# Altium schematic sheet Isolated_RS485.SchDoc
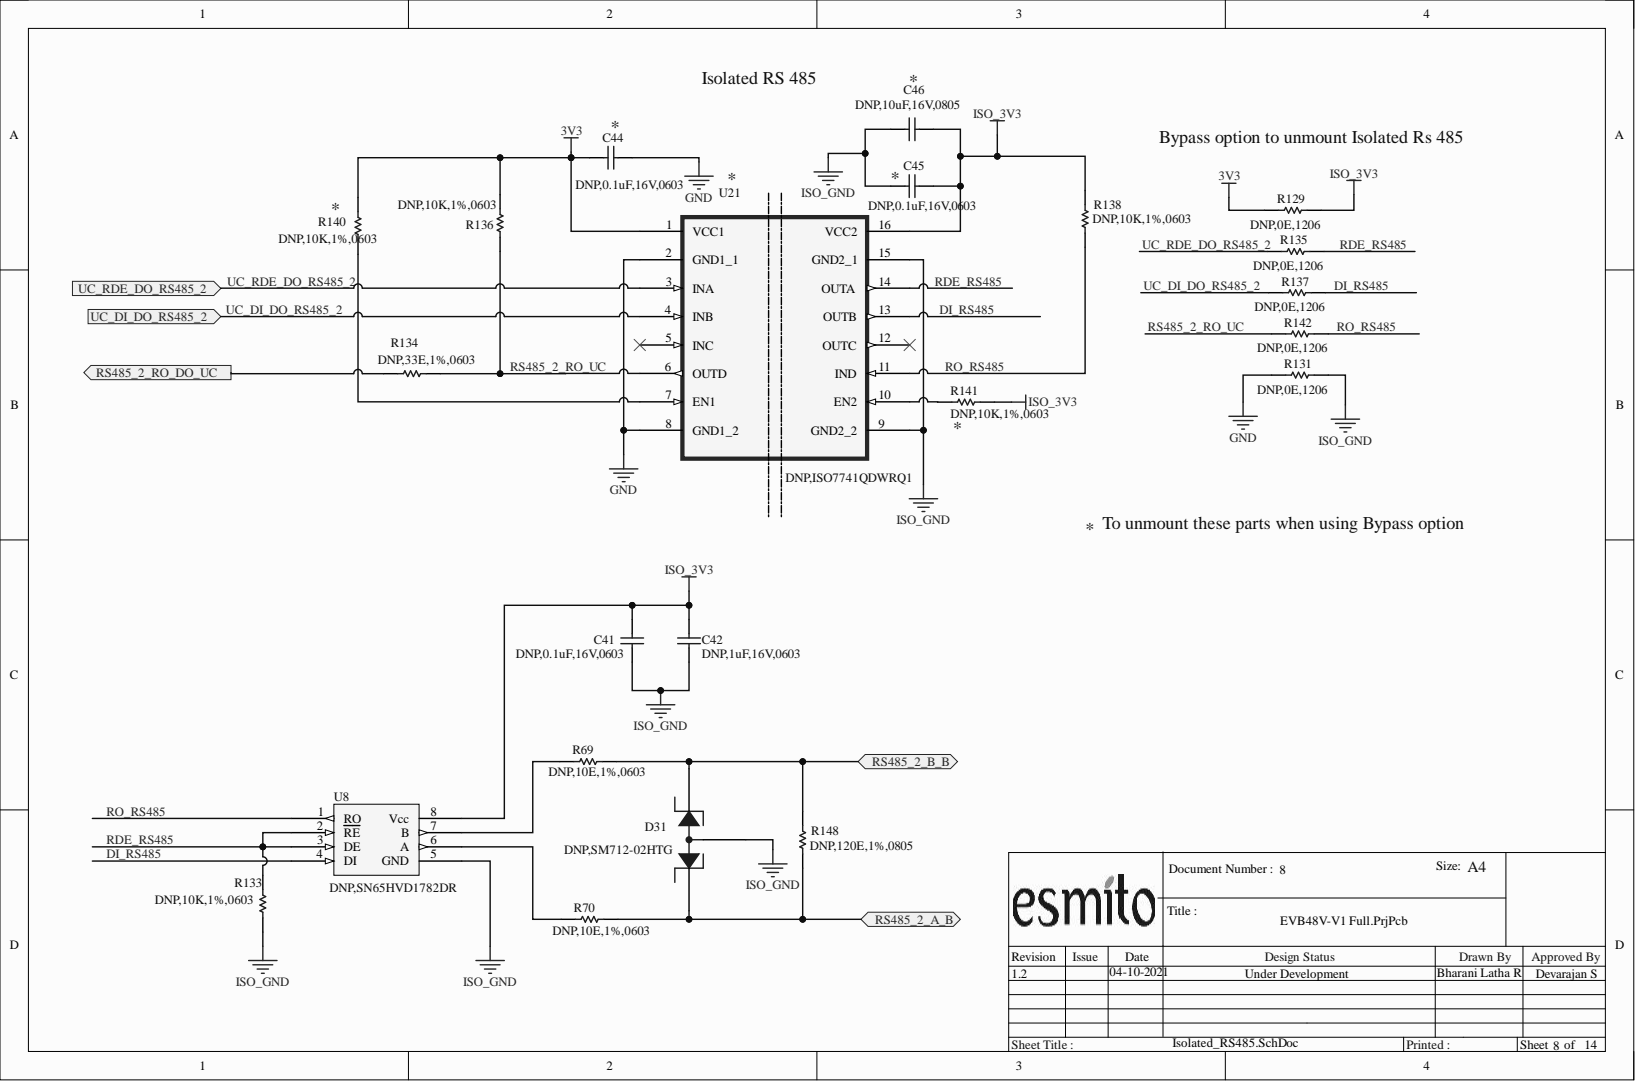
[diagram p0: Isolated_RS485.SchDoc]

## Parts
C41  value DNP,0.1uF,16V,0603  [0603_C_M]  (hidden comment: C_Semi)
C42  value DNP,1uF,16V,0603  [0603_C_M]  (hidden comment: C_Semi)
C44  value DNP,0.1uF,16V,0603  [0603_C_M]  (hidden comment: C_Semi)
C45  value DNP,0.1uF,16V,0603  [0603_C_M]  (hidden comment: C_Semi)
C46  value DNP,10uF,16V,0805  [0805_R_M]  (hidden comment: C_Semi)
D31  SM712-02HTG  value DNP,SM712-02HTG  [SOT23_3_L]
R69  value DNP,10E,1%,0603  [0603_R_M]  (hidden comment: R_Semi)
R70  value DNP,10E,1%,0603  [0603_R_M]  (hidden comment: R_Semi)
R129  R_Semi  [1206_C_M]  (value not drawn)
R131  value DNP,0E,1206  [1206_C_M]  (hidden comment: R_Semi)
R133  value DNP,10K,1%,0603  [0603_R_M]  (hidden comment: R_Semi)
R134  value DNP,33E,1%,0603  [0603_R_M]  (hidden comment: R_Semi)
R135  value DNP,0E,1206  [1206_C_M]  (hidden comment: R_Semi)
R136  value DNP,10K,1%,0603  [0603_R_M]  (hidden comment: R_Semi)
R137  value DNP,0E,1206  [1206_C_M]  (hidden comment: R_Semi)
R138  value DNP,10K,1%,0603  [0603_R_M]  (hidden comment: R_Semi)
R140  value DNP,10K,1%,0603  [0603_R_M]  (hidden comment: R_Semi)
R141  value DNP,10K,1%,0603  [0603_R_M]  (hidden comment: R_Semi)
R142  value DNP,0E,1206  [1206_C_M]  (hidden comment: R_Semi)
R148  value DNP,120E,1%,0805  [0805_R_M]  (hidden comment: R_Semi)
U8  value DNP,SN65HVD1782DR  [SOIC8_M]  (hidden comment: RS485)
U21  ISO7741QDWRQ1  value DNP,ISO7741QDWRQ1  [SOIC127P1030X265-16M]

## Ports
RS485_2_A_B, RS485_2_B_B, RS485_2_RO_DO_UC, UC_DI_DO_RS485_2, UC_RDE_DO_RS485_2

## Nets
3V3: C44.1 R129.2 R136.2 R140.2 U21.1(VCC1)
GND: C44.2 R131.2 U21.2(GND1_1) U21.8(GND1_2)
ISO_3V3: C41.2 C42.2 C45.2 C46.1 R129.1 R138.2 R141.1 U8.8(Vcc) U21.16(VCC2)
ISO_GND: C41.1 C42.1 C45.1 C46.2 D31.3 R131.1 R133.1 U8.5(GND) U21.9(GND2_2) U21.15(GND2_1)
DI_RS485: R137.1 U8.4(DI) U21.13(OUTB)
RDE_RS485: R133.2 R135.1 U8.2(RE) U8.3(DE) U21.14(OUTA)
RO_RS485: R138.1 R142.1 U8.1(RO) U21.11(IND)
RS485_2_A_B: D31.2 R70.1 R148.1 ; port RS485_2_A_B
RS485_2_B_B: D31.1 R69.1 R148.2 ; port RS485_2_B_B
RS485_2_RO_DO_UC: R134.2 ; port RS485_2_RO_DO_UC
RS485_2_RO_UC: R134.1 R136.1 R142.2 U21.6(OUTD)
UC_DI_DO_RS485_2: R137.2 U21.4(INB) ; port UC_DI_DO_RS485_2
UC_RDE_DO_RS485_2: R135.2 U21.3(INA) ; port UC_RDE_DO_RS485_2
NetR69_2: R69.2 U8.7(B)
NetR70_2: R70.2 U8.6(A)
NetR140_1: R140.1 U21.7(EN1)
NetR141_2: R141.2 U21.10(EN2)

Not connected: U21 5(INC) 12(OUTC)

## Library data of the parts
C41 C44 C45 = C_Semi: MLCC - 0603 100nF 16V X7R 10%; Alternate Manufacturer: AVX; Alternate Part Number: 0603YC104K4T2A; Manufacturer: Yageo; Part Number: CC603KRX7R7BB104; Component Kind: Standard; Signal Integrity: Cap Semi; Simulation: CAP; Library Name: Grinntech_Global_Library.IntLib
C42 = C_Semi: MLCC - 0603 1uF 16V X5R 10%; Alternate Manufacturer: Murata; Alternate Part Number: GCM188R71C105KA64D; Manufacturer: Yageo; Part Number: CC603KRX5R7BB105; Component Kind: Standard; Signal Integrity: Cap Semi; Simulation: CAP; Library Name: Grinntech_Global_Library.IntLib
C46 = C_Semi: MLCC - 0805 16V 10uF X7R 10 %; Alternate Manufacturer: Taiyo Yuden; Alternate Part Number: EMK212BB7106KG-T; Manufacturer: Yageo; Part Number: CC0805KKX7R7BB106; Component Kind: Standard; Signal Integrity: Cap Semi; Simulation: CAP; Library Name: Grinntech_Global_Library.IntLib
D31 = SM712-02HTG: ESD Suppressors / TVS Diodes 600W 2 Channel 12V; Alternate Manufacturer: Littelfuse; Manufacturer: STMicroelectronics; Part Number: ESDA6V1L; Alternate Part Number: SM712-02HTG; Component Kind: Standard
R69 R70 = R_Semi: R, THK FLM, 10E, 1%, 250mW, 0603; Manufacturer: ROHM; Part Number: ESR03EZPF10R0; Component Kind: Standard; Signal Integrity: Res Semi; Simulation: RES; Library Name: Grinntech_Global_Library.IntLib
R129 = R_Semi: R, THK FLM, 0E, 5%, 500mW, 1206; Manufacturer: Yageo; Part Number: AC1210JR-070RL; Value: DNP,0E,1206; Component Kind: Standard; Signal Integrity: Res Semi; Simulation: RES; Library Name: Grinntech_Global_Library.IntLib
R131 R135 R137 R142 = R_Semi: R, THK FLM, 0E, 5%, 500mW, 1206; Manufacturer: Yageo; Part Number: AC1210JR-070RL; Component Kind: Standard; Signal Integrity: Res Semi; Simulation: RES; Library Name: Grinntech_Global_Library.IntLib
R133 R136 R138 R140 R141 = R_Semi: R, THK FLM, 10K, 1%, 100mW, 0603; Alternate Manufacturer: Vishay; Alternate Part Number: CRCW060310K0FKEA; Manufacturer: Yageo; Part Number: RC0603FR-0710KL; Component Kind: Standard; Signal Integrity: Res Semi; Simulation: RES; Library Name: Grinntech_Global_Library.IntLib
R134 = R_Semi: R, THK FLM, 33E, 1%, 100mW, 0603; Alternate Manufacturer: Yageo; Alternate Part Number: AC0603FR-0733RL; Part Number: RC0603FR-0733RL; Component Kind: Standard; Manufacturer: Yageo; Signal Integrity: Res Semi; Simulation: RES; Library Name: Grinntech_Global_Library.IntLib
R148 = R_Semi: R, THK FLM, 120E, 1%, 250mW, 0805; Manufacturer: KOA; Part Number: RK73H2ATTD1200F; Component Kind: Standard; Signal Integrity: Res Semi; Simulation: RES; Library Name: Grinntech_Global_Library.IntLib
U8 = RS485_ADM3061E: IC, RS485 Transciever, SOIC-8; Alternate Manufacturer: Analog Devices; Alternate Part Number: ADM3061EARZ; Manufacturer: Texas Instruments; Part Number: SN65HVD1782DR; Component Kind: Standard; Library Name: Grinntech_Global_Lib.IntLib
U21 = ISO7741QDWRQ1: Quad-Channel Digital Isolator; Manufacturer: Texas Instruments; Component Kind: Standard; Part Number: ISO7741QDWRQ1; Library Name: AFE_UC_Active_Conn.SchLib
